# Altium schematic sheet mboard_pwr_ctrl.SchDoc
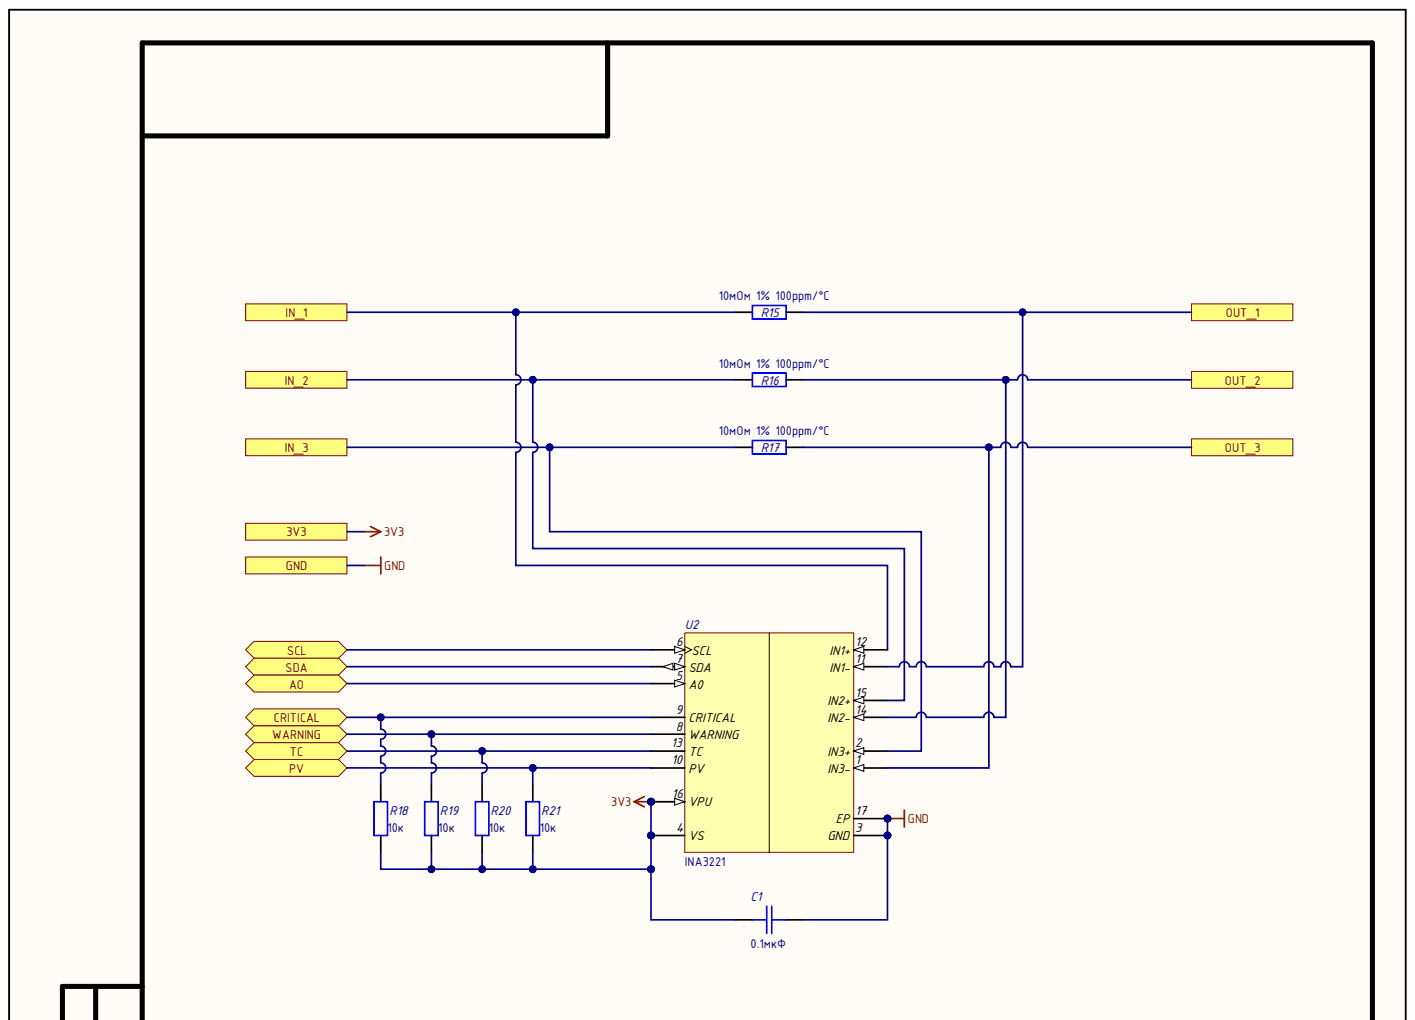
[diagram p0: mboard_pwr_ctrl.SchDoc, top]
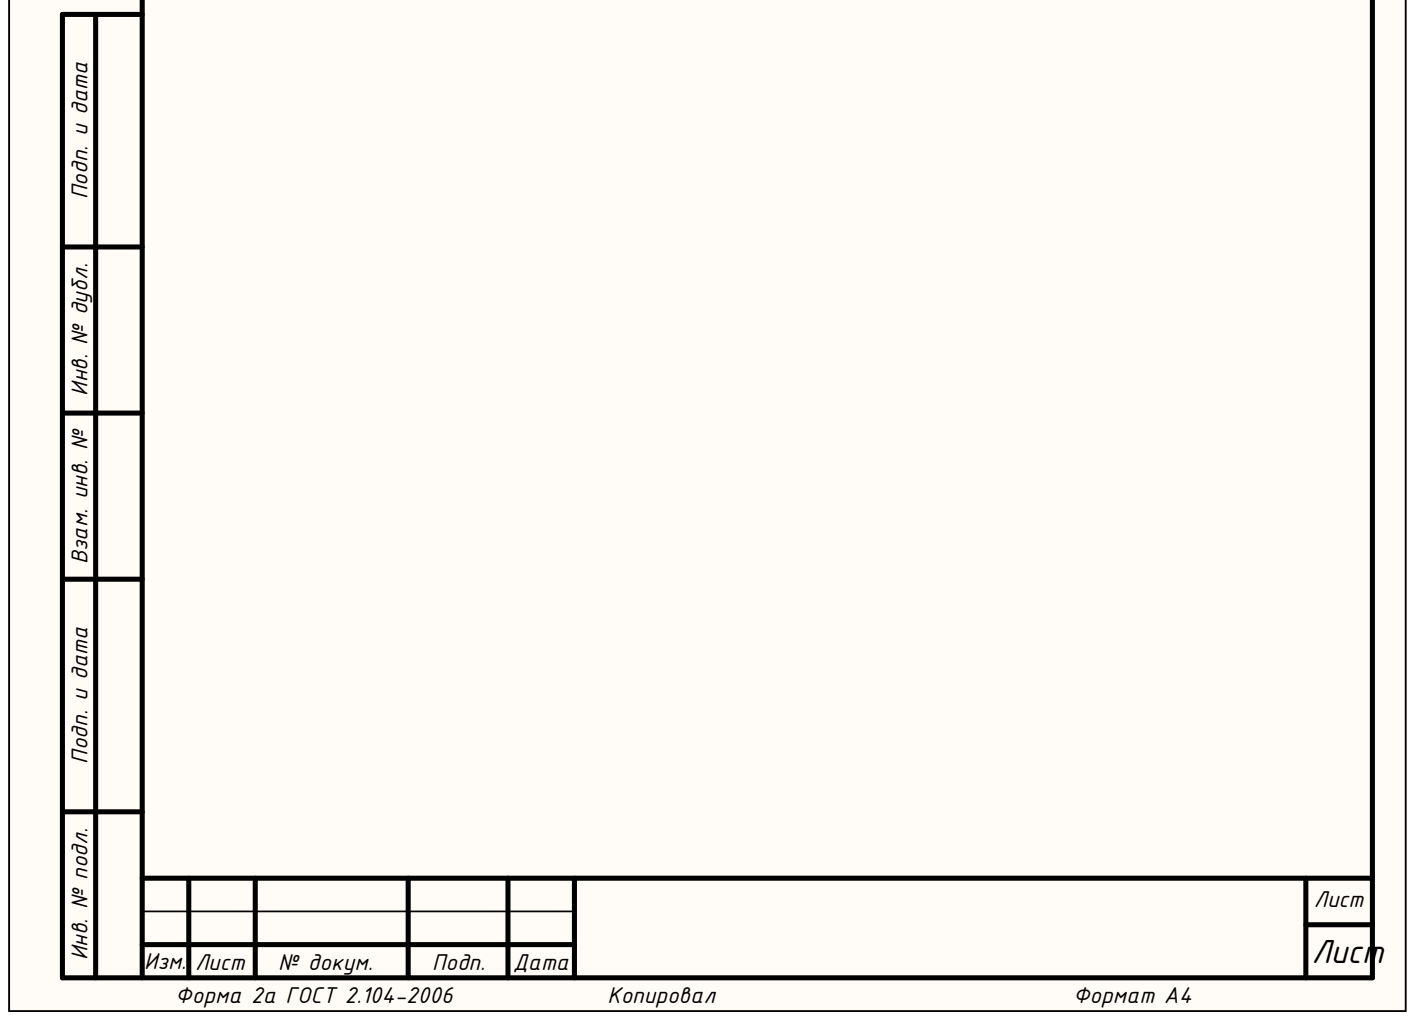
[diagram p1: mboard_pwr_ctrl.SchDoc, bottom]

## Parts
C1  0.1мкФ  [SMD_C0603]
R15  10мОм 1% 100ppm/°C  [SMD_0805]
R16  10мОм 1% 100ppm/°C  [SMD_0805]
R17  10мОм 1% 100ppm/°C  [SMD_0805]
R18  10к  [SMD_0603]
R19  10к  [SMD_0603]
R20  10к  [SMD_0603]
R21  10к  [SMD_0603]
U2  INA3221  [VQFN-16]

## Ports
3V3, AO, CRITICAL, GND, IN_1, IN_2, IN_3, OUT_1, OUT_2, OUT_3, PV, SCL, SDA, TC, WARNING

## Nets
3V3: C1.2 R18.1 R19.1 R20.1 R21.1 U2.4(VS) U2.16(VPU) ; port 3V3
AO: U2.5(A0) ; port AO
CRITICAL: R18.2 U2.9(CRITICAL) ; port CRITICAL
GND: C1.1 U2.3(GND) U2.17(EP) ; port GND
IN_1: R15.2 U2.12(IN1+) ; port IN_1
IN_2: R16.2 U2.15(IN2+) ; port IN_2
IN_3: R17.2 U2.2(IN3+) ; port IN_3
OUT_1: R15.1 U2.11(IN1-) ; port OUT_1
OUT_2: R16.1 U2.14(IN2-) ; port OUT_2
OUT_3: R17.1 U2.1(IN3-) ; port OUT_3
PV: R21.2 U2.10(PV) ; port PV
SCL: U2.6(SCL) ; port SCL
SDA: U2.7(SDA) ; port SDA
TC: R20.2 U2.13(TC) ; port TC
WARNING: R19.2 U2.8(WARNING) ; port WARNING

## Library data of the parts
C1 = Capacitor: Назначение: SMD-конденсатор; Наименование: Конденсатор; Потребление, Вт: 0; Component Kind: Standard; Description: Capacitor; Library Name: Passive.IntLib; Value: 0.1мкФ
R15-R17 = Resistor: PE1206DRM470R01L; Назначение: SMD-резистор; Наименование: Резистор; Потребление, Вт: 0,001; Component Kind: Standard; Library Name: Passive.IntLib; Value: 10мОм 1% 100ppm/°C
R18-R21 = Resistor: Назначение: SMD-резистор; Наименование: Резистор; Потребление, Вт: 0,001; Component Kind: Standard; Description: Resistor; Library Name: Passive.IntLib; Value: 10к
U2 = INA3221: Triple-Channel, High-Side Measurement,  Shunt and Bus Voltage Monitor with I2C- and SMBUS-Compatible Interface; Потребление, Вт: 0.001; Component Kind: Standard; ComponentLink1Description: Data sheet; ComponentLink1URL: https://www.ti.com/lit/ds/symlink/ina3221.pdf?ts=1635579800802&ref_url=https%253A%252F%252Fwww.ti.com%252Fproduct%252FINA3221; Library Name: Power_Monitor.IntLib; Supplier Part Number 1: INA3221AIRGVT; Назначение: Triple-Channel, High-Side Measurement,; Наименование: INA3221
